# Altium schematic sheet [9]USB.SchDoc
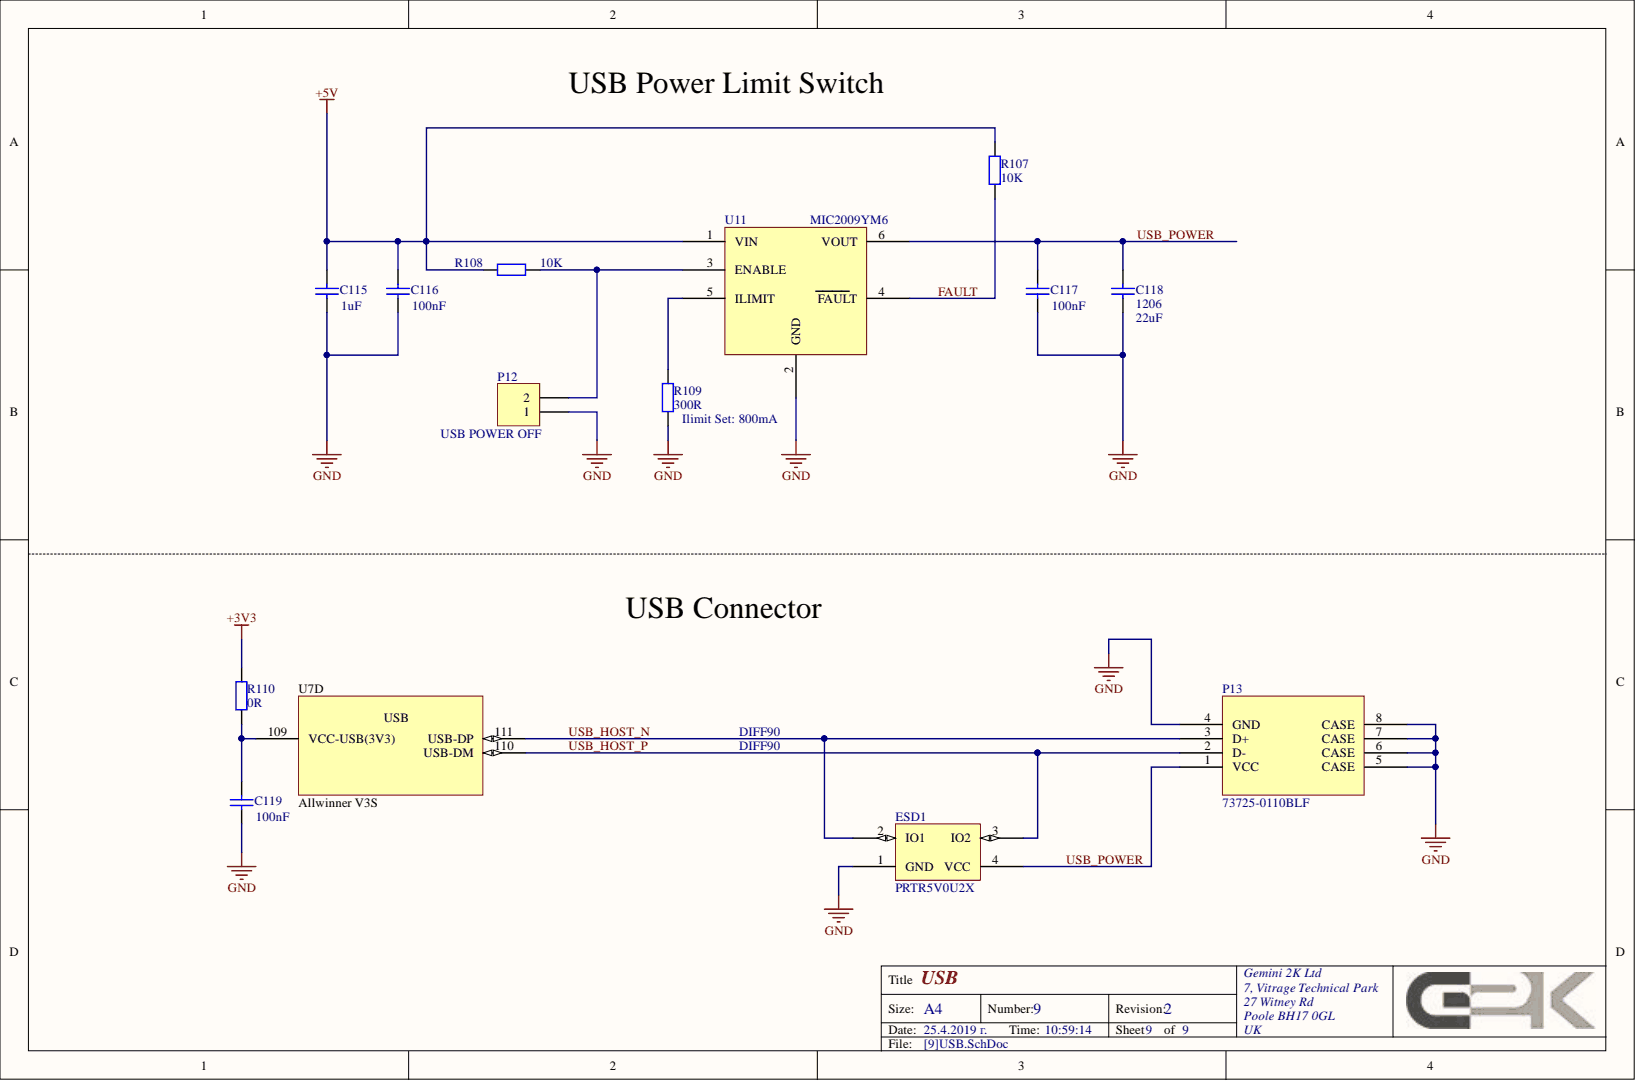
[diagram p0: [9]USB.SchDoc]

## Parts
C115  value 1uF  [1608[0603]]  (hidden comment: 0603)
C116  value 100nF  [1608[0603]]  (hidden comment: 0603)
C117  value 100nF  [1608[0603]]  (hidden comment: 0603)
C118  1206  value 22uF  [C1206]
C119  value 100nF  [1608[0603]]  (hidden comment: 0603)
ESD1  PRTR5V0U2X  [SOT143N]
P12  USB POWER OFF  [HDR1X2]
P13  73725-0110BLF  [73725-0110BLF]
R107  value 10K  [J1-0603]  (hidden comment: 0603)
R108  value 10K  [J1-0603]  (hidden comment: 0603)
R109  value 300R  [J1-0603]  (hidden comment: 0603)
R110  value 0R  [J1-0603]  (hidden comment: 0603)
U7  Allwinner V3S  Manufacturer Name: Allwinner  Manufacturer Part No.: V3S  [LQFP128 E-PAD - Low Density]  (part D)
U11  MIC2009YM6  [SOT26A-6N]

## Nets
+3V3: R110.2
+5V: C115.2 C116.2 R107.1 R108.2 U11.1(VIN)
GND: C115.1 C116.1 C117.1 C118.1 C119.1 ESD1.1(GND) P12.1 P13.4(GND) P13.5(CASE) P13.6(CASE) P13.7(CASE) P13.8(CASE) R109.2 U11.2(GND)
FAULT: R107.2 U11.4(FAULT)
USB_HOST_N: ESD1.2(IO1) P13.3(D+) U7.111(USB-DP)
USB_HOST_P: ESD1.3(IO2) P13.2(D-) U7.110(USB-DM)
USB_POWER: C117.2 C118.2 ESD1.4(VCC) P13.1(VCC) U11.6(VOUT)
NetC119_2: C119.2 R110.1 U7.109(VCC-USB(3V3))
NetP12_2: P12.2 R108.1 U11.3(ENABLE)
NetR109_1: R109.1 U11.5(ILIMIT)

## Library data of the parts
C115 C118 = Cap: Capacitor; Component Kind: Standard; LatestRevisionDate: 17-Jul-2002; LatestRevisionNote: Re-released for DXP Platform.; Library Name: Miscellaneous Devices.IntLib; PackageDescription: Radial Cap, Thru-Hole; 2 Leads; 0.3 in Pin Spacing; PackageReference: RAD-0.3; Published: 8-Jun-2000; Signal Integrity: Cap; Simulation: CAP; Publisher: Altium Limited
  C115: Case Code (in): 0603; Distributor Link: https://eu.mouser.com/ProductDetail/Taiyo-Yuden/LMK107B7105KA-T?qs=sGAEpiMZZMvsSlwiRhF8qgJCQaFFkNE%2fsetabqB5pzo%3d
  C118: Case Code (in): 1206; Distributor Link: https://eu.mouser.com/ProductDetail/Taiyo-Yuden/EMK316BB7226ML-T?qs=sGAEpiMZZMvsSlwiRhF8qty1BJkHnpVYblTC1OBiWryHLK40sNpKgw%3d%3d
C116 C117 C119 = Cap: Capacitor; Note: We have them in Bulgaria; Case Code (in): 0603; Component Kind: Standard; Distributor Link: http://uk.farnell.com/kemet/c0603x104k4ractu/cap-mlcc-x7r-100nf-16v-0603/dp/1414027; LatestRevisionDate: 17-Jul-2002; LatestRevisionNote: Re-released for DXP Platform.; Library Name: Miscellaneous Devices.IntLib; PackageDescription: Radial Cap, Thru-Hole; 2 Leads; 0.3 in Pin Spacing; PackageReference: RAD-0.3; Published: 8-Jun-2000; Signal Integrity: Cap; Simulation: CAP; Publisher: Altium Limited
ESD1 = PRTR5V0U2X: Manufacturer Name: Nexperia; Manufacturer Part No.: PRTR5V0U2X,215; Component Kind: Standard; Distributor Link: https://www.mouser.bg/ProductDetail/Nexperia/PRTR5V0U2X215?qs=sGAEpiMZZMvKM5ialpXrmha212gV%252bN%252bb; Library Name: NX1.SchLib
P12 = Header 2: Header, 2-Pin; Value: N.F.; Component Kind: Standard; LatestRevisionDate: 17-Jul-2002; LatestRevisionNote: Re-released for DXP Platform.; Library Name: Miscellaneous Connectors.IntLib; Signal Integrity: Connector; Publisher: Altium Limited
P13 = 73725-0110BLF: USB A Connector; Manufacturer Name: AMPHENOL ICC (FCI); Component Kind: Standard; Distributor Link: https://uk.farnell.com/amphenol-icc-fci/73725-0110blf/usb-2-0-type-a-receptacle-th/dp/2112380; Manufacturer Part No.: 73725-0110BLF; Library Name: PapayaPi.SchLib
R107 R108 = Res2: Resistor; Case Code (in): 0603; Component Kind: Standard; Distributor Link: http://uk.farnell.com/multicomp/mcwr06x1002ftl/res-thick-film-10k-1-0-1w-0603/dp/2447230; LatestRevisionDate: 17-Jul-2002; LatestRevisionNote: Re-released for DXP Platform.; Library Name: Miscellaneous Devices.IntLib; PackageDescription: Axial Device, Thru-Hole; 2 Leads; 0.4 in Pin Spacing; PackageReference: AXIAL-0.4; Published: 8-Jun-2000; Signal Integrity: Res; Simulation: RESISTOR; Publisher: Altium Limited
R109 = Res2: Resistor; Ilimit Set: 800mA; Case Code (in): 0603; Component Kind: Standard; Distributor Link: https://uk.farnell.com/multicomp/mcwr06x3000ftl/res-300r-1-0-1w-thick-film/dp/2447331; LatestRevisionDate: 17-Jul-2002; LatestRevisionNote: Re-released for DXP Platform.; Library Name: Miscellaneous Devices.IntLib; PackageDescription: Axial Device, Thru-Hole; 2 Leads; 0.4 in Pin Spacing; PackageReference: AXIAL-0.4; Published: 8-Jun-2000; Signal Integrity: Res; Simulation: RESISTOR; Publisher: Altium Limited
R110 = Res2: Resistor; Note: We have them in Bulgaria; Case Code (in): 0603; Component Kind: Standard; Distributor Link: http://uk.farnell.com/yageo/rc0603fr-070rl/res-thick-film-0r-1-0-1w-0603/dp/2309106; LatestRevisionDate: 17-Jul-2002; LatestRevisionNote: Re-released for DXP Platform.; Library Name: Miscellaneous Devices.IntLib; PackageDescription: Axial Device, Thru-Hole; 2 Leads; 0.4 in Pin Spacing; PackageReference: AXIAL-0.4; Published: 8-Jun-2000; Signal Integrity: Res; Simulation: RESISTOR; Publisher: Altium Limited
U11 = MIC2009YM6: Usb High Side switch, with adjustable current limit.; Manufacturer Name: MICROCHIP; Component Kind: Standard; Distributor Link: https://uk.farnell.com/microchip/mic2009ym6-tr/load-switch-hs-5-5v-0-2-2a-6sot23/dp/2509889RL; Manufacturer Part No.: MIC2009YM6; Library Name: PapayaPi.SchLib
U7 = Allwinner V3S: Component Kind: Standard; Library Name: Allwinner.SchLib
